# Altium schematic sheet Sheet1.SchDoc
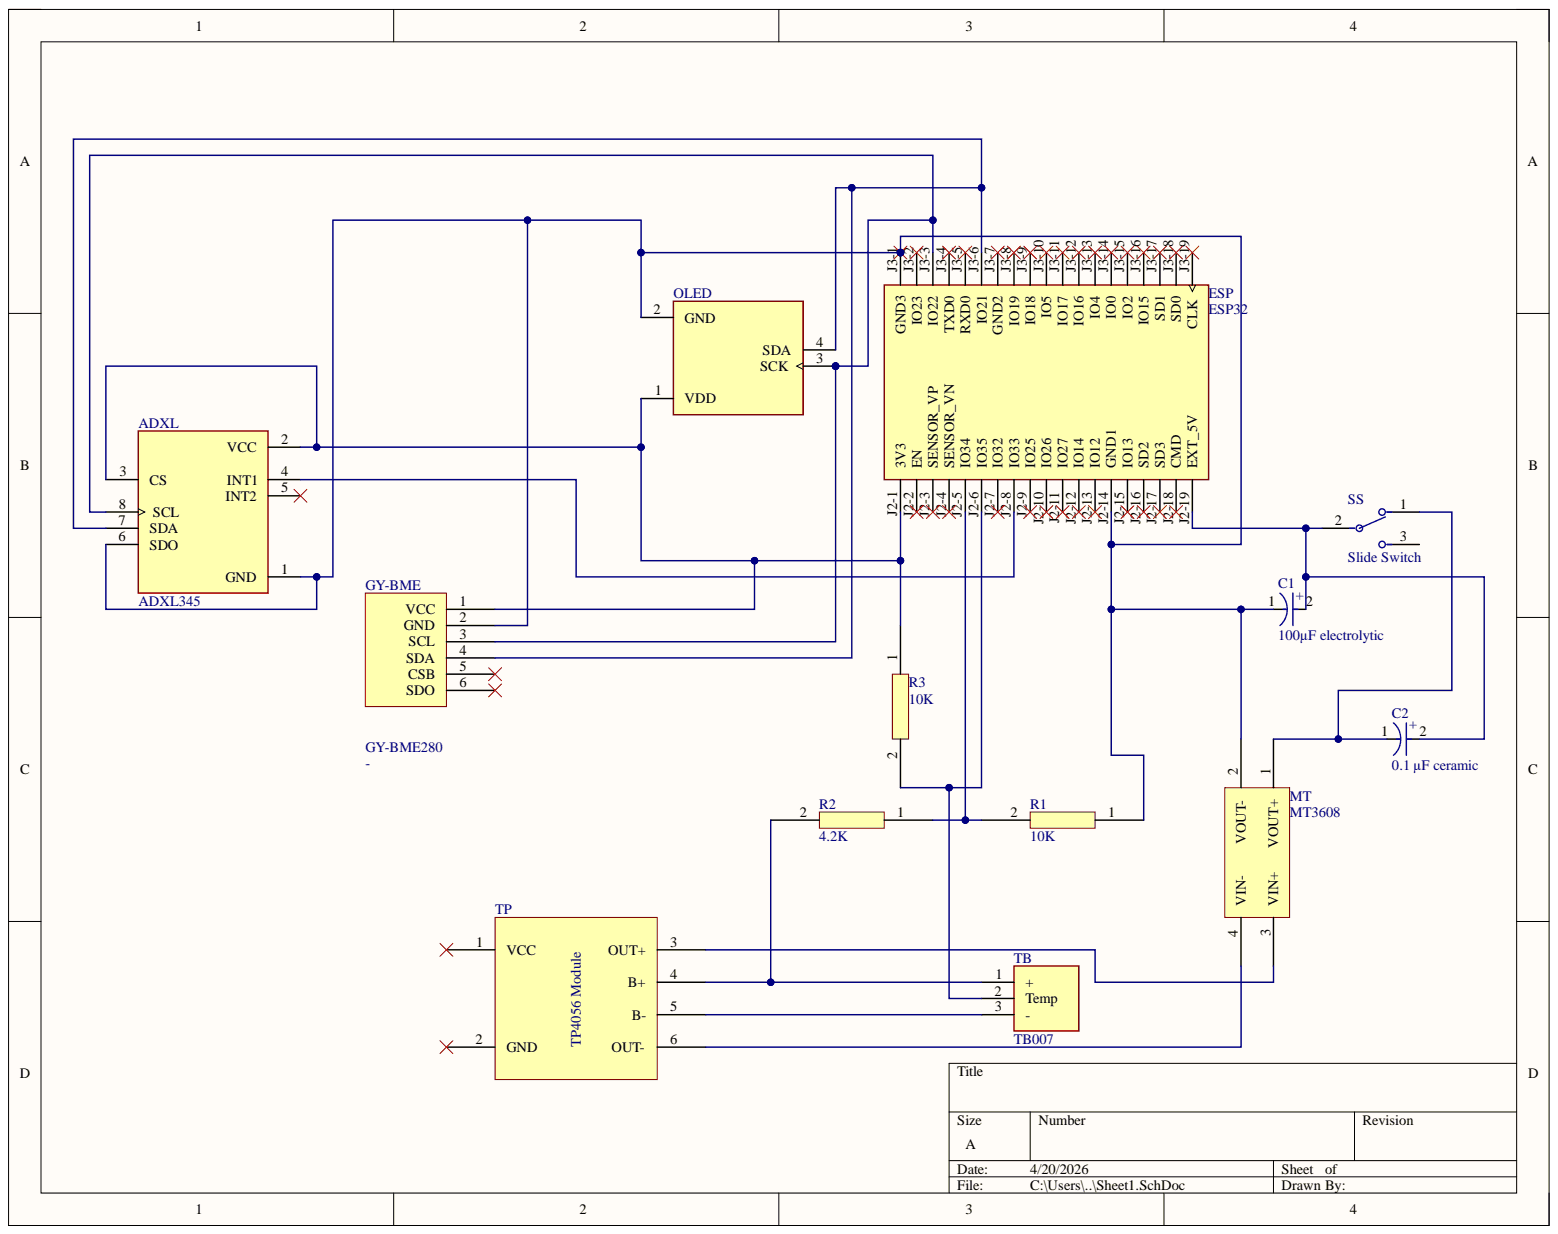
[diagram p0: Sheet1.SchDoc]

## Parts
ADXL  ADXL345  [ADXL345]
C1  100µF electrolytic  [FP-RAD-TH-D_6_3_0_5-L_11-MFG]
C2  0.1 µF ceramic  [FP-RAD-TH-D_6_3_0_5-L_11-MFG]
ESP  ESP32  [MODULE_ESP32-DEVKITC-32D]
GY-BME  -  [GY-BME280]
MT  MT3608  [MT3608]
OLED  (no value)  [LCD_OLED_128X64_1.3_I2C]
R1  10K  [resistor]
R2  4.2K  [resistor]
R3  10K  [resistor]
SS  Slide Switch  [FP-25436NAH6-MFG]
TB  TB007  [TB007]
TP  (no value)  [TP4056Module]

## Nets
NetADXL_1: ADXL.1(GND) ADXL.6(SDO) C1.1 ESP.J2-14(GND1) ESP.J3-1(GND3) GY-BME.2(GND) MT.2(VOUT-) OLED.2(GND) R1.1
NetADXL_2: ADXL.2(VCC) ADXL.3(CS) ESP.J2-1(3V3) GY-BME.1(VCC) OLED.1(VDD) R3.1
NetADXL_4: ADXL.4(INT1) ESP.J2-8(IO33)
NetADXL_7: ADXL.7(SDA) ESP.J3-6(IO21) GY-BME.4(SDA) OLED.4(SDA)
NetADXL_8: ADXL.8(SCL) ESP.J3-3(IO22) GY-BME.3(SCL) OLED.3(SCK)
NetC1_2: C1.2 C2.2 ESP.J2-19(EXT_5V) SS.2
NetC2_1: C2.1 MT.1(VOUT+) SS.1
NetESP_J2-5: ESP.J2-5(IO34) R1.2 R2.1
NetESP_J2-6: ESP.J2-6(IO35) R3.2 TB.2
NetMT_3: MT.3(VIN+) TP.3(OUT+)
NetMT_4: MT.4(VIN-) TP.6(OUT-)
NetR2_2: R2.2 TB.1 TP.4(B+)
NetTB_3: TB.3 TP.5(B-)

Not connected: ADXL 5(INT2); ESP J2-2(EN) J2-3(SENSOR_VP) J2-4(SENSOR_VN) J2-7(IO32) J2-9(IO25) J2-10(IO26) J2-11(IO27) J2-12(IO14) J2-13(IO12) J2-15(IO13) J2-16(SD2) J2-17(SD3) J2-18(CMD) J3-2(IO23) J3-4(TXD0) J3-5(RXD0) J3-7(GND2) J3-8(IO19) J3-9(IO18) J3-10(IO5) J3-11(IO17) J3-12(IO16) J3-13(IO4) J3-14(IO0) J3-15(IO2) J3-16(IO15) J3-17(SD1) J3-18(SD0) J3-19(CLK); GY-BME 5(CSB) 6(SDO); SS 3; TP 1(VCC) 2(GND)

## Library data of the parts
C1 C2 = CMP-05427-000856-1: Aluminum Electrolytic Capacitors (Radial Lead Type) 100uF ±20% 25V; ESR (Equivalent Series Resistance): 130mR; Lead Diameter: 0.5mm; Life (Hours): 2000Hour; Polarity: Polar; Ripple Current: 455mA; Capacitance: 100uF; Case/Package: Radial; Component Kind: Standard; Diameter: 6mm; Height - Seated (Max): 0.5inch; Impedance: 130mR; Lead Pitch: 5mm; Length: 11mm; Library Name: Altium Content Vault; Max Operating Temperature: 105°C; Min Operating Temperature: -40°C; Mount: Through Hole; Package Quantity: 2000; Packaging: Tape and Reel; RoHS Compliant: Yes; Series: FM; Termination: Radial; Tolerance: 20%; Voltage Rating: 25V; Voltage Rating (DC): 25V
GY-BME = GY-BME280: Component Kind: Standard; Library Name: Schlib1.SchLib
OLED = OLED_128X64_1.3_I2C: Non-Touch Graphic LCD Display Module Transmissive White OLED, Monochrome I2C 1.3 (33.02mm) 128 x 64; MF: UNIVERSAL-SOLDER Electronics Ltd; MP: OLED 128x64 1.3&quot; I2C; Check_prices: https://www.snapeda.com/parts/OLED%20128x64%201.3%22%20I2C/UNIVERSAL-SOLDER+Electronics+Ltd/view-part/?ref=eda; Component Kind: Standard; Library Name: OLED_128X64_1.3_I2C.IntLib; Package: None; SnapEDA_Link: https://www.snapeda.com/parts/OLED%20128x64%201.3%22%20I2C/UNIVERSAL-SOLDER+Electronics+Ltd/view-part/?ref=snap
R1-R3 = resistor: Component Kind: Standard; Library Name: Schlib1.SchLib
SS = CMP-47022-000005-1: SWITCH SLIDE SPDT 4A 125V; Actuator Length: 6mm; Actuator Material: Nylon; Actuator Type: Standard; Contact Resistance: 10mR; Current Rating: 1A; Electrical Life: 10000Cycles; Max Voltage Rating (AC): 250V; Max Voltage Rating (DC): 30V; Mechanical Life: 20000Cycles; Switch Function: On-On; Switch Travel: 4mm; Component Kind: Standard; Contact Material: Silver; Library Name: Altium Content Vault; Max Operating Temperature: 85°C; Min Operating Temperature: -40°C; Mount: Through Hole; Orientation: Straight; Package Quantity: 100; Packaging: Bulk; Series: 25000N; Termination: PC Pin; Throw Configuration: SPDT; Voltage Rating (AC): 125V; Voltage Rating (DC): 30V
TP = TP4056: Component Kind: Standard; Library Name: Schlib1.SchLib
ADXL = ADXL345: Component Kind: Standard; Library Name: Schlib1.SchLib
ESP = ESP32: Component Kind: Standard; Library Name: Schlib1.SchLib
MT = MT3608: Component Kind: Standard; Library Name: Schlib1.SchLib
TB = TB007: Component Kind: Standard; Library Name: Schlib1.SchLib
